# Altium schematic sheet Sheet3.SchDoc
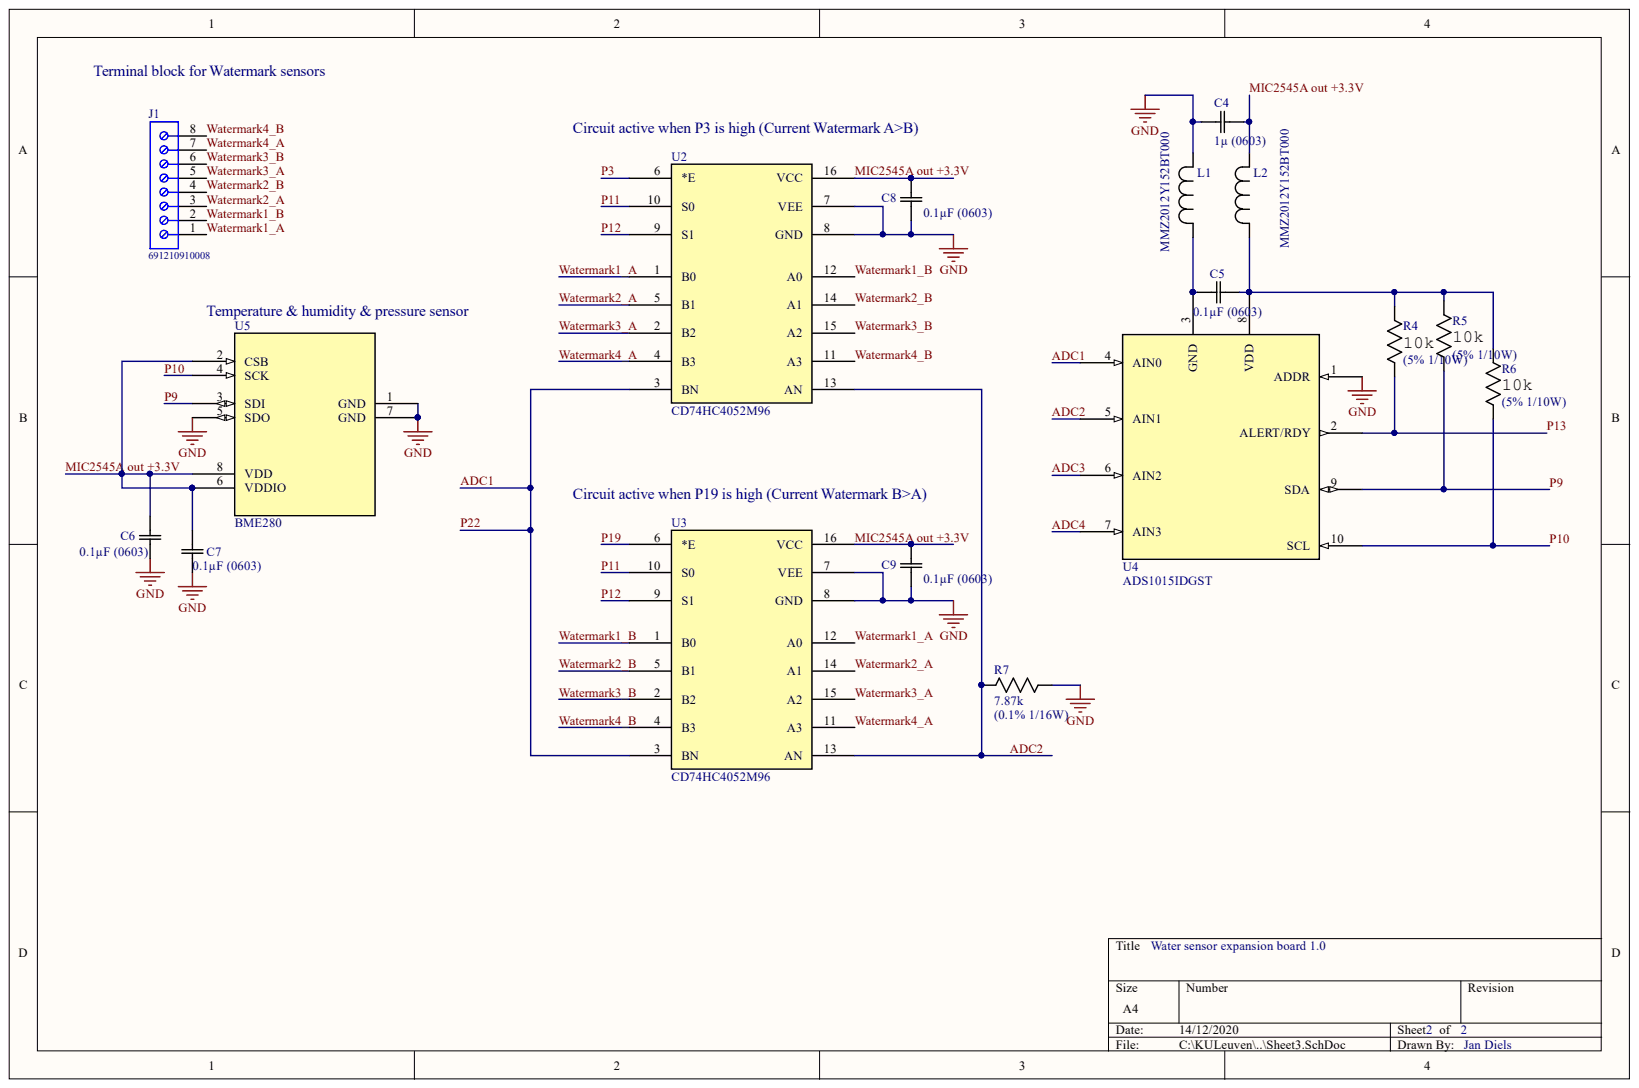
[diagram p0: Sheet3.SchDoc]

## Parts
C4  1µ (0603)  [YAG_CC0603]
C5  0.1µF (0603)  [cap_UMK107ABJ474]
C6  0.1µF (0603)  [cap_UMK107ABJ474]
C7  0.1µF (0603)  [cap_UMK107ABJ474]
C8  0.1µF (0603)  [cap_UMK107ABJ474]
C9  0.1µF (0603)  [cap_UMK107ABJ474]
J1  691210910008  [691210910008]
L1  MMZ2012Y152BT000  [IND_2012]
L2  MMZ2012Y152BT000  [IND_2012]
R4  value 10k  [RES_CRCW_0603]  (hidden comment: Part Number: CRCW060310K0JNEBC)
R5  value 10k  extra: (5% 1/10W)  [RES_CRCW_0603]  (hidden comment: Part Number: CRCW060310K0JNEBC)
R6  value 10k  extra: (5% 1/10W)  [RES_CRCW_0603]  (hidden comment: Part Number: CRCW060310K0JNEBC)
R7  7.87k  Extra info: (0.1% 1/16W)  [RC0603N]
U2  CD74HC4052M96  [D16]
U3  CD74HC4052M96  [D16]
U4  ADS1015IDGST  [DGS10]
U5  BME280  [DFN8_0p65_2p5SQ]

## Nets
GND: C4.1 C6.1 C7.1 C8.1 C9.1 L1.1 R7.1 U2.7(VEE) U2.8(GND) U3.7(VEE) U3.8(GND) U4.1(ADDR) U5.1(GND) U5.5(SDO) U5.7(GND)
ADC1: U2.3(BN) U3.3(BN) U4.4(AIN0)
ADC2: R7.2 U2.13(AN) U3.13(AN) U4.5(AIN1)
ADC3: U4.6(AIN2)
ADC4: U4.7(AIN3)
MIC2545A OUT +3.3V: C4.2 C6.2 C7.2 C8.2 C9.2 L2.1 U2.16(VCC) U3.16(VCC) U5.2(CSB) U5.6(VDDIO) U5.8(VDD)
P3: U2.6(*E)
P9: R5.2 U4.9(SDA) U5.3(SDI)
P10: R6.2 U4.10(SCL) U5.4(SCK)
P11: U2.10(S0) U3.10(S0)
P12: U2.9(S1) U3.9(S1)
P13: R4.2 U4.2(ALERT/RDY)
P19: U3.6(*E)
WATERMARK1_A: J1.1 U2.1(B0) U3.12(A0)
WATERMARK1_B: J1.2 U2.12(A0) U3.1(B0)
WATERMARK2_A: J1.3 U2.5(B1) U3.14(A1)
WATERMARK2_B: J1.4 U2.14(A1) U3.5(B1)
WATERMARK3_A: J1.5 U2.2(B2) U3.15(A2)
WATERMARK3_B: J1.6 U2.15(A2) U3.2(B2)
WATERMARK4_A: J1.7 U2.4(B3) U3.11(A3)
WATERMARK4_B: J1.8 U2.11(A3) U3.4(B3)
NetC5_1: C5.1 L2.2 R4.1 R5.1 R6.1 U4.8(VDD)
NetC5_2: C5.2 L1.2 U4.3(GND)

## Library data of the parts
C4 = CC0603KRX5R8BB10: 0603 Multilayer Ceramic Capacitors MLCC - SMD/SMT 1.0uF 25V X5R 10%; Manufacturer_Part_Number: CC0603KRX5R8BB105; Built_By: EMA_UL_Team; Type: DEV; Vendor: Yageo; Component Kind: Standard; Library Name: 2019-08-15_15-08-20.SchLib; RefDes: RefDes; Value: Value; Copyright: Copyright (C) 2018 Accelerated Designs. All rights reserved
C5-C9 = EMK107B7105KAHT: 0.1uF MLCC  16V X7R 10% 0603; Built_By: EMA_UL_Team; Type: DEV; Value: 0.1µ; Vendor: Taiyo Yuden; Component Kind: Standard; Library Name: OpenWater1.SchLib; Manufacturer_Part_Number: EMK107B7105KAHT; RefDes: RefDes; Copyright: Copyright (C) 2018 Accelerated Designs. All rights reserved
J1 = 691210910008: Serie 2109 - 2.54 mm Horizontal Entry with Rising Cage Clamp WR-TBL, 8 pin; Pin Number: 8; Pitch: 2.54 mm; Component Kind: Standard; ComponentLink1Description: Manufacturer; ComponentLink1URL: http://www.we-online.de; ComponentLink2Description: Datasheet; ComponentLink2URL: http://katalog.we-online.de/em/datasheet/6912109100xx.pdf; Library Name: Altium_TBL_RISING_CAGE_SYSTEM (rev18b).IntLib; Mounting Technology: THT; Operating Temperature: -40 to 105 degC; Packaging: Box; Part Number: 691210910008; Published Date: June 2018; Publisher: Wurth Elektronik
L1 L2 = MMZ2012Y152BT000: Ferrite Bead 1.5K OHM 25%; Built_By: EMA_UL_Team; Type: DEV; Vendor: TDK; Component Kind: Standard; Library Name: OpenWater1.SchLib; Manufacturer_Part_Number: MMZ2012Y152BT000; RefDes: RefDes; Value: Value; Copyright: Copyright (C) 2018 Accelerated Designs. All rights reserved
R4 = CRCW060310K0JNEBC: 10Kohms 5% Thick Film Resistor 1/10Watt; Built_By: EMA_UL_Team; extra: (5% 1/10W); Vendor: Vishay; Component Kind: Standard; Library Name: OpenWater1.SchLib; Manufacturer_Part_Number: CRCW060310K0JNEBC; RefDes: RefDes; Type: DEV; Copyright: Copyright (C) 2018 Accelerated Designs. All rights reserved
R5 R6 = CRCW060310K0JNEBC: 10Kohms 5% Thick Film Resistor 1/10Watt; Built_By: EMA_UL_Team; Vendor: Vishay; Component Kind: Standard; Library Name: OpenWater1.SchLib; Manufacturer_Part_Number: CRCW060310K0JNEBC; RefDes: RefDes; Type: DEV; Copyright: Copyright (C) 2018 Accelerated Designs. All rights reserved
R7 = PCF-W0603LF-03-7871-B-P-LT: 7K87 Ohms 0.1% Thin Film Resistor - SMD 0603  25 PPM; Built_By: EMA_UL_Team; Note: Footprint and Step taken from other 603 resistor; Vendor: TT Electronics; Component Kind: Standard; Library Name: OpenWater1.SchLib; Manufacturer_Part_Number: PCF-W0603LF-03-7871-B-P-LT; Mouser No: 66-PCFW0603LF037871B; RefDes: RefDes; Type: DEV; Value: 7.87k; Copyright: Copyright (C) 2018 Accelerated Designs. All rights reserved
U2 U3 = CD74HC4052M96: High Speed CMOS Differential 4-Channel Analog Multiplexer/Demultiplexer 16-SOIC; Built_By: EMA_UL_Team; VENDOR: Texas Instruments; Component Kind: Standard; Library Name: OpenWater1.SchLib; MANUFACTURER_PART_NUMBER: CD74HC4052M96; RefDes: RefDes; Type: DEV; Value: Value; Copyright: Copyright (C) 2018 Accelerated Designs. All rights reserved
U4 = ADS1015IDGST: 12-BIT ADC - 4 Channel I2C, MSOP-10; Built_By: EMA_UL_Team; Vendor: Texas Instruments; Component Kind: Standard; Library Name: OpenWater1.SchLib; Manufacturer_Part_Number: ADS1015IDGST; RefDes: RefDes; Type: DEV; Value: Value; Copyright: Copyright (C) 2018 Accelerated Designs. All rights reserved
U5 = BME280: Bosch humidity, temperature and pressuer sensor; Built_By: EMA_UL_Team; Component Kind: Standard; Library Name: OpenWater1.SchLib; Manufacturer_Part_Number: BME280; RefDes: RefDes; Type: DEV; Value: Value; Vendor: Bosch; Copyright: Copyright (C) 2018 Accelerated Designs. All rights reserved
